# Altium schematic sheet EIT_Multiplex_32_pt2.SchDoc
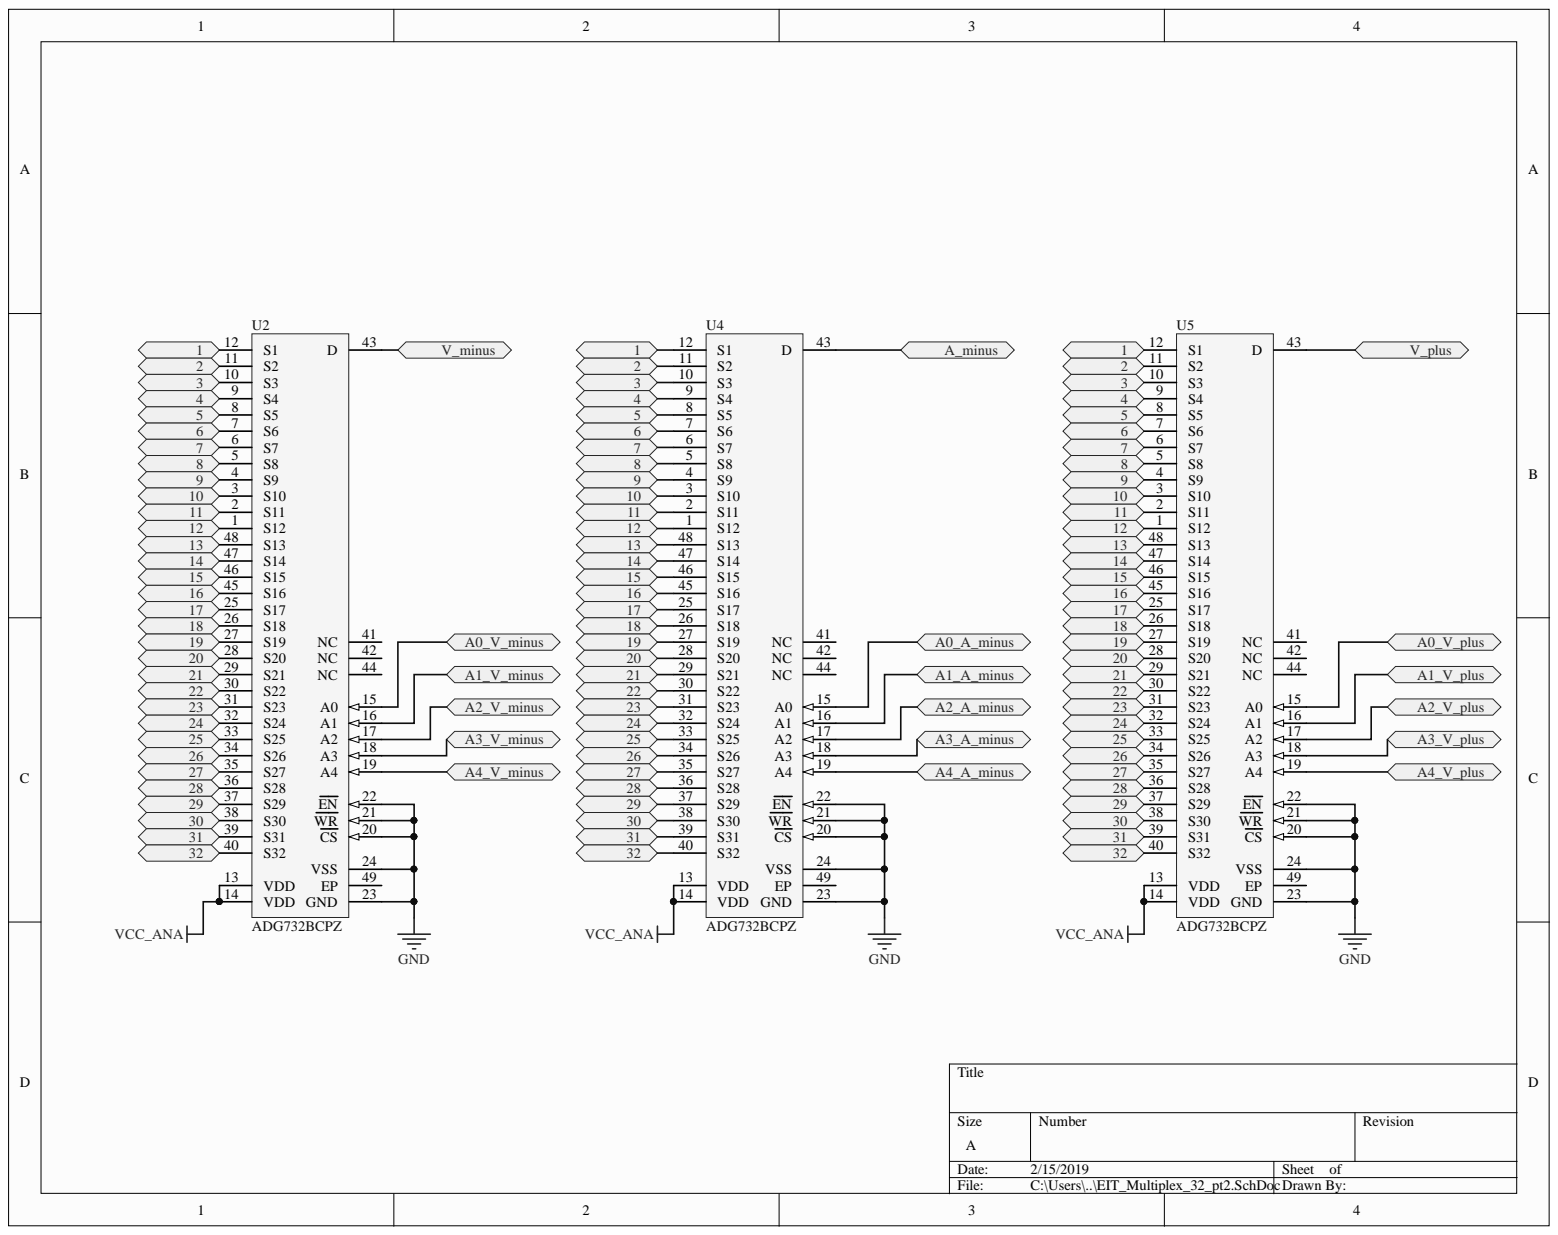
[diagram p0: EIT_Multiplex_32_pt2.SchDoc]

## Parts
U2  ADG732BCPZ  [ADI-CP-48-1_N-noviainpad]
U4  ADG732BCPZ  [ADI-CP-48-1_N-noviainpad]
U5  ADG732BCPZ  [ADI-CP-48-1_N-noviainpad]

## Ports
1, 2, 3, 4, 5, 6, 7, 8, 9, 10, 11, 12, 13, 14, 15, 16, 17, 18, 19, 20, 21, 22, 23, 24, 25, 26, 27, 28, 29, 30, 31, 32, A0_A_MINUS, A0_V_MINUS, A0_V_PLUS, A1_A_MINUS, A1_V_MINUS, A1_V_PLUS, A2_A_MINUS, A2_V_MINUS, A2_V_PLUS, A3_A_MINUS, A3_V_MINUS, A3_V_PLUS, A4_A_MINUS, A4_V_MINUS, A4_V_PLUS, A_MINUS, V_MINUS, V_PLUS

## Nets
GND: U2.20(CS) U2.21(WR) U2.22(EN) U2.23(GND) U2.24(VSS) U4.20(CS) U4.21(WR) U4.22(EN) U4.23(GND) U4.24(VSS) U5.20(CS) U5.21(WR) U5.22(EN) U5.23(GND) U5.24(VSS)
VCC_ANA: U2.13(VDD) U2.14(VDD) U4.13(VDD) U4.14(VDD) U5.13(VDD) U5.14(VDD)
1: U2.12(S1) U4.12(S1) U5.12(S1) ; port 1
2: U2.11(S2) U4.11(S2) U5.11(S2) ; port 2
3: U2.10(S3) U4.10(S3) U5.10(S3) ; port 3
4: U2.9(S4) U4.9(S4) U5.9(S4) ; port 4
5: U2.8(S5) U4.8(S5) U5.8(S5) ; port 5
6: U2.7(S6) U4.7(S6) U5.7(S6) ; port 6
7: U2.6(S7) U4.6(S7) U5.6(S7) ; port 7
8: U2.5(S8) U4.5(S8) U5.5(S8) ; port 8
9: U2.4(S9) U4.4(S9) U5.4(S9) ; port 9
10: U2.3(S10) U4.3(S10) U5.3(S10) ; port 10
11: U2.2(S11) U4.2(S11) U5.2(S11) ; port 11
12: U2.1(S12) U4.1(S12) U5.1(S12) ; port 12
13: U2.48(S13) U4.48(S13) U5.48(S13) ; port 13
14: U2.47(S14) U4.47(S14) U5.47(S14) ; port 14
15: U2.46(S15) U4.46(S15) U5.46(S15) ; port 15
16: U2.45(S16) U4.45(S16) U5.45(S16) ; port 16
17: U2.25(S17) U4.25(S17) U5.25(S17) ; port 17
18: U2.26(S18) U4.26(S18) U5.26(S18) ; port 18
19: U2.27(S19) U4.27(S19) U5.27(S19) ; port 19
20: U2.28(S20) U4.28(S20) U5.28(S20) ; port 20
21: U2.29(S21) U4.29(S21) U5.29(S21) ; port 21
22: U2.30(S22) U4.30(S22) U5.30(S22) ; port 22
23: U2.31(S23) U4.31(S23) U5.31(S23) ; port 23
24: U2.32(S24) U4.32(S24) U5.32(S24) ; port 24
25: U2.33(S25) U4.33(S25) U5.33(S25) ; port 25
26: U2.34(S26) U4.34(S26) U5.34(S26) ; port 26
27: U2.35(S27) U4.35(S27) U5.35(S27) ; port 27
28: U2.36(S28) U4.36(S28) U5.36(S28) ; port 28
29: U2.37(S29) U4.37(S29) U5.37(S29) ; port 29
30: U2.38(S30) U4.38(S30) U5.38(S30) ; port 30
31: U2.39(S31) U4.39(S31) U5.39(S31) ; port 31
32: U2.40(S32) U4.40(S32) U5.40(S32) ; port 32
NetU2_15: U2.15(A0) ; port A0_V_MINUS
NetU2_16: U2.16(A1) ; port A1_V_MINUS
NetU2_17: U2.17(A2) ; port A2_V_MINUS
NetU2_18: U2.18(A3) ; port A3_V_MINUS
NetU2_19: U2.19(A4) ; port A4_V_MINUS
NetU2_43: U2.43(D) ; port V_MINUS
NetU4_15: U4.15(A0) ; port A0_A_MINUS
NetU4_16: U4.16(A1) ; port A1_A_MINUS
NetU4_17: U4.17(A2) ; port A2_A_MINUS
NetU4_18: U4.18(A3) ; port A3_A_MINUS
NetU4_19: U4.19(A4) ; port A4_A_MINUS
NetU4_43: U4.43(D) ; port A_MINUS
NetU5_15: U5.15(A0) ; port A0_V_PLUS
NetU5_16: U5.16(A1) ; port A1_V_PLUS
NetU5_17: U5.17(A2) ; port A2_V_PLUS
NetU5_18: U5.18(A3) ; port A3_V_PLUS
NetU5_19: U5.19(A4) ; port A4_V_PLUS
NetU5_43: U5.43(D) ; port V_PLUS

Not connected: U2 41(NC) 42(NC) 44(NC) 49(EP); U4 41(NC) 42(NC) 44(NC) 49(EP); U5 41(NC) 42(NC) 44(NC) 49(EP)

## Library data of the parts
U2 U4 U5 = ADG732BCPZ: 1.8 to 5.5 V / +/-2.5 V, 4 Ohm, 32-Channel Analog Multiplexer, -40 to 85 degC, 48-pin QFN (CP-48-1), Tray; Manufacturer 1: Analog Devices; Bandwidth (Hz): 18M; Max Analog Signal Range: Vss to Vdd; Ron (ohms): 4; Supply V Guar Performance: Dual_(+/-2.5V), Single_(+3V), Single_(+5V); t transition (s): 23n; Code_JEDEC: MO-220-VKKD-2; Component Kind: Standard; ComponentLink1Description: Manufacturer URL; ComponentLink1URL: http://www.analog.com/en/index.html; ComponentLink2Description: Datasheet; ComponentLink2URL: http://www.analog.com/static/imported-files/data_sheets/ADG726_732.pdf; ComponentLink3Description: Package Specification; ComponentLink3URL: http:/www.analog.com/static/imported-files/packages/PKG_PDF/LFCSP(CP)/CP-48/CP_48_1.pdf; DatasheetVersion: Rev. 0; Manufacturer Part Number 1: ADG732BCPZ; Mounting Technology: Surface Mount; PackageDescription: 48-Pin Lead Frame Chip Scale Package, Body 7 x 7 mm, Pitch 0.5 mm; PackageReference: CP-48-1; Packing: Tray; Supplier 1: Digi-Key; Supplier 2: Newark; Supplier 3: Mouser; Supplier Part Number 1: ADG732BCPZ-ND; Supplier Part Number 2: 12M7830; Supplier Part Number 3: 584-ADG732BCPZ; Library Name: EIT_lib.SchLib
